# Altium schematic sheet HV Fuses.SchDoc
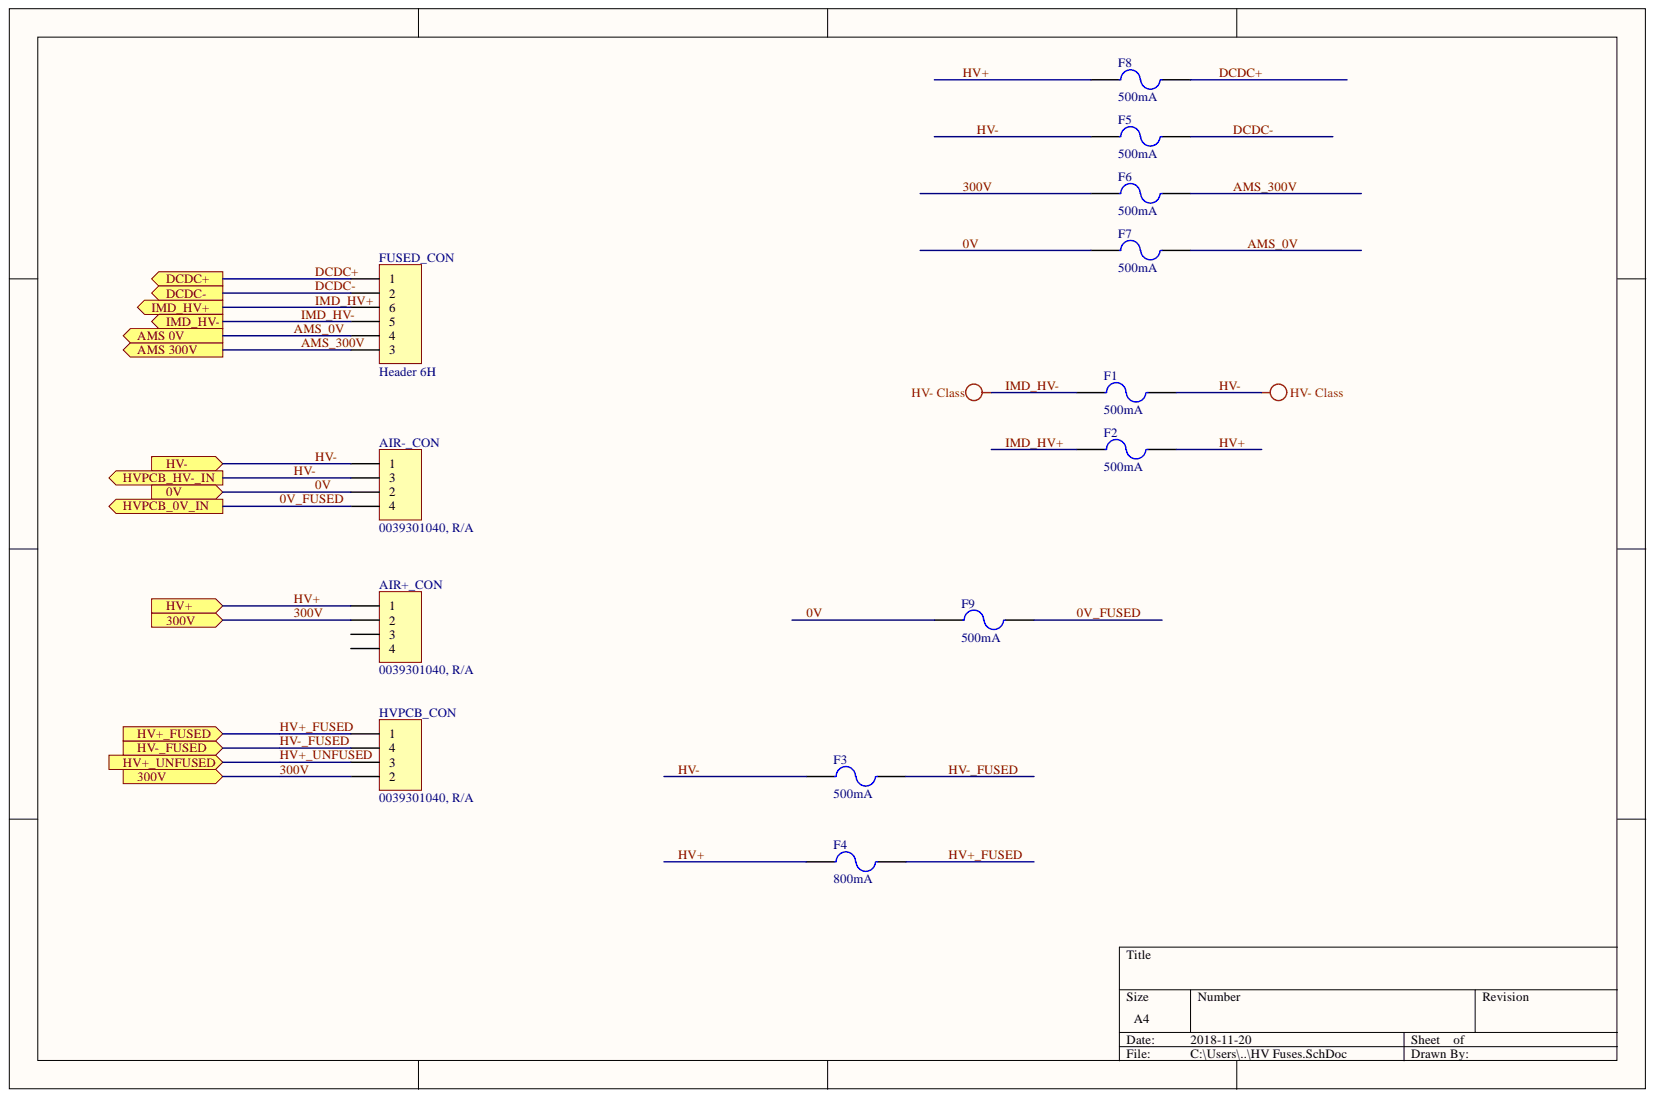
[diagram p0: HV Fuses.SchDoc]

## Parts
AIR+_CON  0039301040, R/A  [Molex Mini Fit Jr - 4 Pin, r/a]
AIR-_CON  0039301040, R/A  [Molex Mini Fit Jr - 4 Pin, r/a]
F1  500mA  [FUSEHOLDER 5X20]
F2  500mA  [FUSEHOLDER 5X20]
F3  500mA  [FUSEHOLDER 5X20]
F4  800mA  [FUSEHOLDER 5X20]
F5  500mA  [FUSEHOLDER 5X20]
F6  500mA  [FUSEHOLDER 5X20]
F7  500mA  [FUSEHOLDER 5X20]
F8  500mA  [FUSEHOLDER 5X20]
F9  500mA  [FUSEHOLDER 5X20]
FUSED_CON  Header 6H  [Molex Mini Fit Jr - 6 Pin, Right Angle]
HVPCB_CON  0039301040, R/A  [Molex Mini Fit Jr - 4 Pin, r/a]

## Ports
0V, 300V, AMS 0V, AMS 300V, DCDC+, DCDC-, HV+, HV+_FUSED, HV+_UNFUSED, HV-, HV-_FUSED, HVPCB_0V_IN, HVPCB_HV-_IN, IMD_HV+, IMD_HV-

## Nets
0V: AIR-_CON.2 F7.1 F9.1 ; port 0V
0V_FUSED: AIR-_CON.4 F9.2 ; port HVPCB_0V_IN
300V: AIR+_CON.2 F6.1 HVPCB_CON.2 ; port 300V
AMS_0V: F7.2 FUSED_CON.4 ; port AMS 0V
AMS_300V: F6.2 FUSED_CON.3 ; port AMS 300V
DCDC+: F8.2 FUSED_CON.1 ; port DCDC+
DCDC-: F5.2 FUSED_CON.2 ; port DCDC-
HV+: AIR+_CON.1 F2.2 F4.1 F8.1 ; port HV+
HV+_FUSED: F4.2 HVPCB_CON.1 ; port HV+_FUSED
HV+_UNFUSED: HVPCB_CON.3 ; port HV+_UNFUSED
HV-: AIR-_CON.1 AIR-_CON.3 F1.2 F3.1 F5.1 ; port HV-, port HVPCB_HV-_IN
HV-_FUSED: F3.2 HVPCB_CON.4 ; port HV-_FUSED
IMD_HV+: F2.1 FUSED_CON.6 ; port IMD_HV+
IMD_HV-: F1.1 FUSED_CON.5 ; port IMD_HV-

Not connected: AIR+_CON 3 4

## Library data of the parts
AIR+_CON AIR-_CON HVPCB_CON = Header 4H: Header, 4-Pin, Right Angle; Component Kind: Standard; LatestRevisionDate: 17-Jul-2002; LatestRevisionNote: Re-released for DXP Platform.; Library Name: Miscellaneous Connectors.IntLib; Signal Integrity: Connector; Publisher: Altium Limited
F1-F9 = Fuse 2: 0031.8201: FUSE BLOK CARTRIDGE 500V 10A PCB; Component Kind: Standard; LatestRevisionDate: 17-Jul-2002; LatestRevisionNote: Re-released for DXP Platform.; Library Name: Miscellaneous Devices.IntLib; PackageDescription: Thru-Hole; 2 Leads; PackageReference: PIN-W2/E2.8; Published: 8-Jun-2000; Simulation: FUSE; Publisher: Altium Limited
  F1 F2 F5-F8: Supplier 1: Digi-Key; Supplier Part Number 1: 283-2757-ND
FUSED_CON = Header 6H: Header, 6-Pin, Right Angle; Component Kind: Standard; LatestRevisionDate: 17-Jul-2002; LatestRevisionNote: Re-released for DXP Platform.; Library Name: Miscellaneous Connectors.IntLib; Signal Integrity: Connector; Publisher: Altium Limited
